# Altium schematic sheet TPVSI_Control_Part.SchDoc(TPVSI_Control_Part)
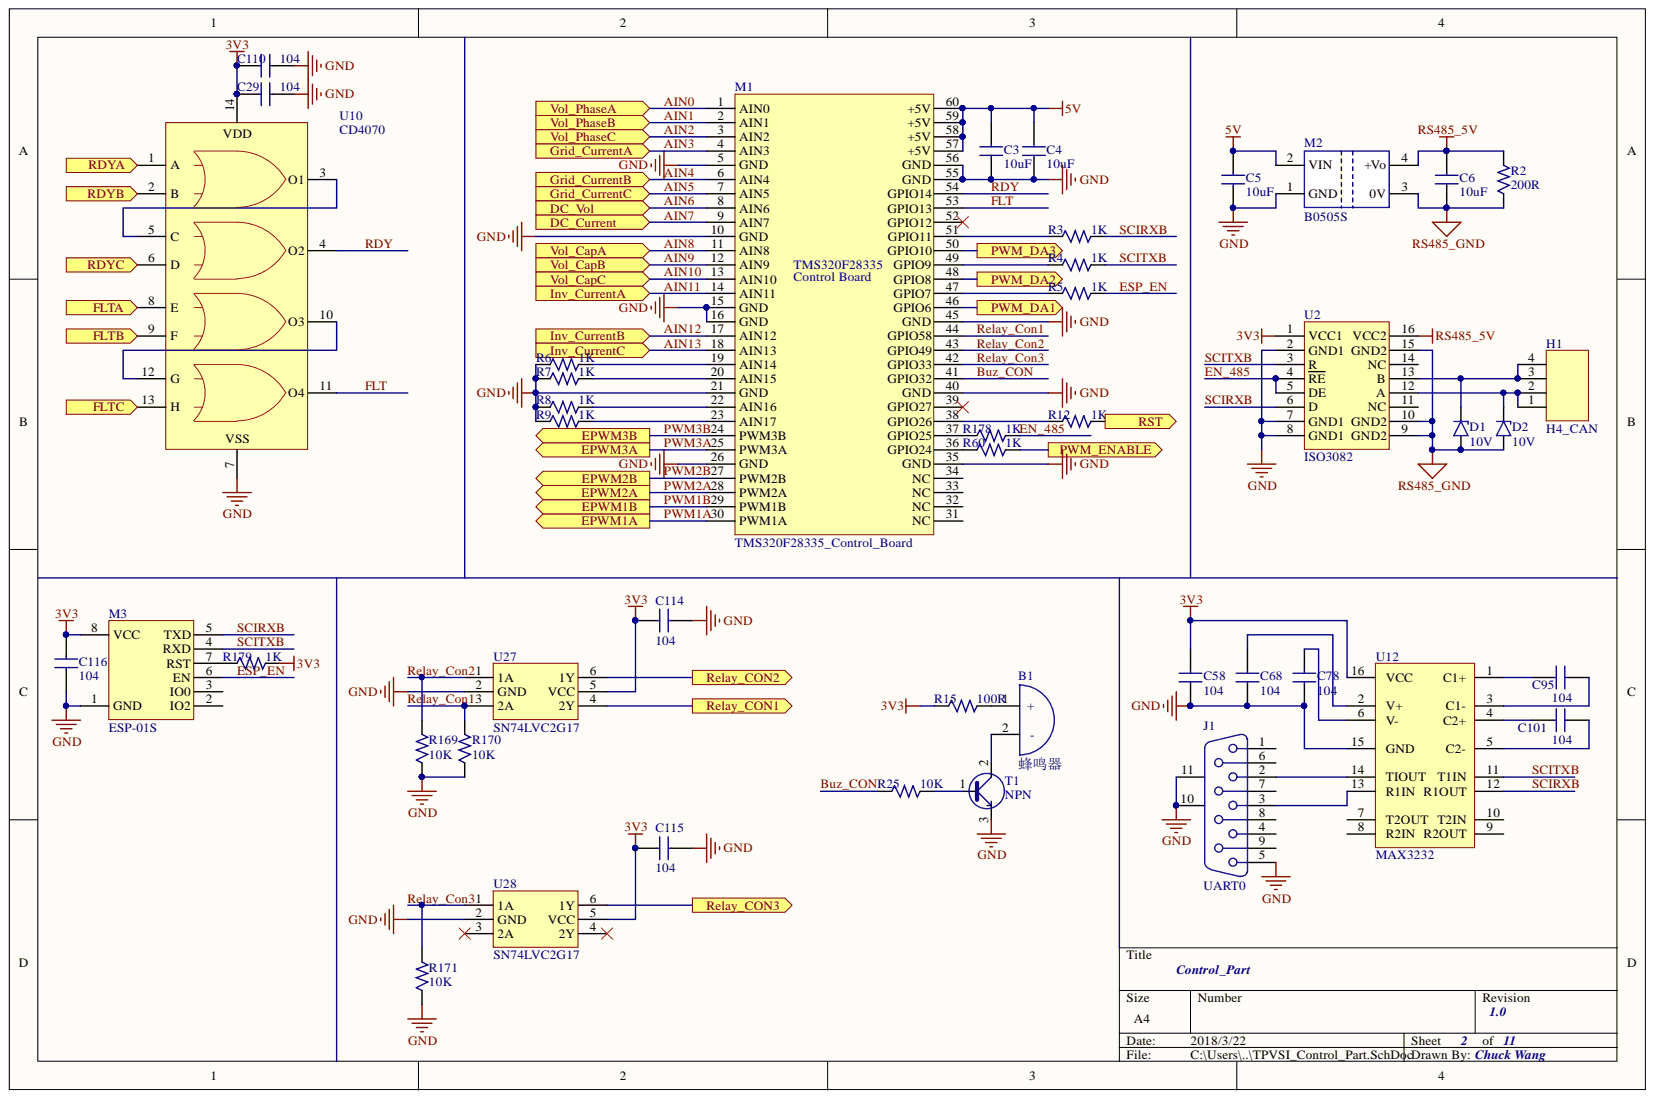
[diagram p0: TPVSI_Control_Part.SchDoc]

## Parts
B1  蜂鸣器  [Buz_9032]
C3  10uF  [1210]
C4  10uF  [1210]
C5  10uF  [1210]
C6  10uF  [1210]
C29  104  [C-RAD-0603]
C58  104  [C-RAD-0603]
C68  104  [C-RAD-0603]
C78  104  [C-RAD-0603]
C95  104  [C-RAD-0603]
C101  104  [C-RAD-0603]
C110  104  [C-RAD-0603]
C114  104  [C-RAD-0603]
C115  104  [C-RAD-0603]
C116  104  [C-RAD-0603]
D1  TVS  [DIODE-SMA]  (value not drawn)
D2  TVS  [DIODE-SMA]  (value not drawn)
H1  H4_CAN  [H4_CAN]
J1  UART0  [串口接口]
M1  TMS320F28335_Control_Board  [TMS320F28335_Control_Board]
M2  B0505S  [b0505s]
M3  ESP-01S  [ESP-01S]
R2  200R  [1206]
R3  1K  [0603_1]
R4  1K  [0603_1]
R5  1K  [0603_1]
R6  1K  [0603_1]
R7  1K  [0603_1]
R8  1K  [0603_1]
R9  1K  [0603_1]
R12  1K  [0603_1]
R15  100R  [1206]
R25  10K  [0603_1]
R60  1K  [0603_1]
R169  10K  [0603_1]
R170  10K  [0603_1]
R171  10K  [0603_1]
R178  1K  [0603_1]
R179  1K  [0603_1]
T1  NPN  [SOT-23]
U2  ISO3082  [SOIC16-WideBody]
U10  CD4070  [SOP-14N]
U12  MAX3232  [NSO16]
U27  SN74LVC2G17  [SOT23-6]
U28  SN74LVC2G17  [SOT23-6]

## Ports
DC_Current, DC_Vol, EPWM1A, EPWM1B, EPWM2A, EPWM2B, EPWM3A, EPWM3B, FLTA, FLTB, FLTC, Grid_CurrentA, Grid_CurrentB, Grid_CurrentC, Inv_CurrentA, Inv_CurrentB, Inv_CurrentC, PWM_DA1, PWM_DA2, PWM_DA3, PWM_ENABLE, RDYA, RDYB, RDYC, Relay_CON1, Relay_CON2, Relay_CON3, RST, Vol_CapA, Vol_CapB, Vol_CapC, Vol_PhaseA, Vol_PhaseB, Vol_PhaseC

## Nets
3V3: C29.1 C58.2 C110.1 C114.1 C115.1 C116.2 M3.8(VCC) R15.1 R179.2 U2.1(VCC1) U10.14 U12.16(VCC) U27.5(VCC) U28.5(VCC)
5V: C3.2 C4.2 C5.2 M1.57(+5V) M1.58(+5V) M1.59(+5V) M1.60(+5V) M2.2(VIN)
GND: C3.1 C4.1 C5.1 C29.2 C58.1 C68.1 C78.1 C110.2 C114.2 C115.2 C116.1 J1.5 J1.10 J1.11 M1.5(GND) M1.10(GND) M1.15(GND) M1.16(GND) M1.21(GND) M1.26(GND) M1.35(GND) M1.40(GND) M1.45(GND) M1.55(GND) M1.56(GND) M2.1(GND) M3.1(GND) R6.1 R7.1 R8.1 R9.1 R169.1 R170.1 R171.1 T1.3 U2.2(GND1) U2.7(GND1) U2.8(GND1) U10.7 U12.15(GND) U27.2(GND) U28.2(GND)
RS485_5V: C6.2 M2.4(+Vo) R2.2 U2.16(VCC2)
RS485_GND: C6.1 D1.1 D2.1 M2.3(0V) R2.1 U2.9(GND2) U2.10(GND2) U2.15(GND2)
AIN0: M1.1(AIN0) ; port Vol_PhaseA
AIN1: M1.2(AIN1) ; port Vol_PhaseB
AIN2: M1.3(AIN2) ; port Vol_PhaseC
AIN3: M1.4(AIN3) ; port Grid_CurrentA
AIN4: M1.6(AIN4) ; port Grid_CurrentB
AIN5: M1.7(AIN5) ; port Grid_CurrentC
AIN6: M1.8(AIN6) ; port DC_Vol
AIN7: M1.9(AIN7) ; port DC_Current
AIN8: M1.11(AIN8) ; port Vol_CapA
AIN9: M1.12(AIN9) ; port Vol_CapB
AIN10: M1.13(AIN10) ; port Vol_CapC
AIN11: M1.14(AIN11) ; port Inv_CurrentA
AIN12: M1.17(AIN12) ; port Inv_CurrentB
AIN13: M1.18(AIN13) ; port Inv_CurrentC
Buz_CON: M1.41(GPIO32) R25.1
EN_485: R178.2 U2.4(RE) U2.5(DE)
ESP_EN: M3.6(EN) R5.2
FLT: M1.53(GPIO13) U10.11(O4)
FLTA: U10.8(E) ; port FLTA
FLTB: U10.9(F) ; port FLTB
FLTC: U10.13(H) ; port FLTC
PWM1A: M1.30(PWM1A) ; port EPWM1A
PWM1B: M1.29(PWM1B) ; port EPWM1B
PWM2A: M1.28(PWM2A) ; port EPWM2A
PWM2B: M1.27(PWM2B) ; port EPWM2B
PWM3A: M1.25(PWM3A) ; port EPWM3A
PWM3B: M1.24(PWM3B) ; port EPWM3B
PWM_DA1: M1.46(GPIO6) ; port PWM_DA1
PWM_DA2: M1.48(GPIO8) ; port PWM_DA2
PWM_DA3: M1.50(GPIO10) ; port PWM_DA3
PWM_ENABLE: R60.2 ; port PWM_ENABLE
RDY: M1.54(GPIO14) U10.4(O2)
RDYA: U10.1(A) ; port RDYA
RDYB: U10.2(B) ; port RDYB
RDYC: U10.6(D) ; port RDYC
Relay_CON1: U27.4(2Y) ; port Relay_CON1
Relay_Con1: M1.44(GPIO58) R170.2 U27.3(2A)
Relay_CON2: U27.6(1Y) ; port Relay_CON2
Relay_Con2: M1.43(GPIO49) R169.2 U27.1(1A)
Relay_CON3: U28.6(1Y) ; port Relay_CON3
Relay_Con3: M1.42(GPIO33) R171.2 U28.1(1A)
RST: R12.2 ; port RST
SCIRXB: M3.5(TXD) R3.2 U2.6(D) U12.12(R1OUT)
SCITXB: M3.4(RXD) R4.2 U2.3(R) U12.11(T1IN)
NetB1_1: B1.1 R15.2
NetB1_2: B1.2 T1.2
NetC68_2: C68.2 U12.2(V+)
NetC78_2: C78.2 U12.6(V-)
NetC95_1: C95.1 U12.3(C1-)
NetC95_2: C95.2 U12.1(C1+)
NetC101_1: C101.1 U12.5(C2-)
NetC101_2: C101.2 U12.4(C2+)
NetD1_2: D1.2 H1.3 H1.4 U2.13(B)
NetD2_2: D2.2 H1.1 H1.2 U2.12(A)
NetJ1_2: J1.2 U12.14(TIOUT)
NetJ1_3: J1.3 U12.13(R1IN)
NetM1_19: M1.19(AIN14) R6.2
NetM1_20: M1.20(AIN15) R7.2
NetM1_22: M1.22(AIN16) R8.2
NetM1_23: M1.23(AIN17) R9.2
NetM1_36: M1.36(GPIO24) R60.1
NetM1_37: M1.37(GPIO25) R178.1
NetM1_38: M1.38(GPIO26) R12.1
NetM1_47: M1.47(GPIO7) R5.1
NetM1_49: M1.49(GPIO9) R4.1
NetM1_51: M1.51(GPIO11) R3.1
NetM3_7: M3.7(RST) R179.1
NetR25_2: R25.2 T1.1
NetU10_3: U10.3(O1) U10.5(C)
NetU10_10: U10.10(O3) U10.12(G)

Not connected: J1 1 4 6 7 8 9; M1 31(NC) 32(NC) 33(NC) 34(NC) 39(GPIO27) 52(GPIO12); M3 2(IO2) 3(IO0); U2 11(NC) 14(NC); U12 7(T2OUT) 8(R2IN) 9(R2OUT) 10(T2IN); U28 3(2A) 4(2Y)

## Library data of the parts
B1 = Buzzer: Component Kind: Standard; Library Name: Chu_16.IntLib
C3-C6 C29 C58 C68 C78 C95 C101 C110 C114-C116 = cap: Component Kind: Standard; Library Name: Chu_16.IntLib
D1 D2 = Diode_TVS: Component Kind: Standard; : 10V; Library Name: Chu_16.IntLib
J1 = 串口: Receptacle Assembly, 9 Position, Right Angle; Positions: 9; PackageDocument: O; Published: 15-Jun-2001; PackageReference: 788750; Orientation: Right Angle; LatestRevisionNote: Re-released for DXP Platform.; LatestRevisionDate: 17-Jul-2002; Gender: Receptacle; DatasheetDocument: 1-Sep-1999; Component Kind: Standard; Publisher: Altium Limited; Library Name: 机器人队元件库 .IntLib
M3 = ESP-01S: WIFI模块; Component Kind: Standard; Library Name: Chu_16.IntLib
R2-R9 R12 R15 R25 R60 R169-R171 R178 R179 = Res: Component Kind: Standard; Library Name: Chu_16.IntLib
T1 = NPN_BJT: Component Kind: Standard; Library Name: Chu_16.IntLib
U27 U28 = SN74LVC3G07: Component Kind: Standard; Library Name: Chu_16.IntLib
H1 = H4_CAN: Component Kind: Standard; Library Name: 机器人队元件库 .IntLib
M1 = TMS320F28335_Control_Board: Component Kind: Standard; Library Name: Chu_16.IntLib
M2 = B0505S: Component Kind: Standard; Library Name: Chu_16.IntLib
U2 = ISO3082: Component Kind: Standard; Library Name: Chu_16.IntLib
U10 = CD4070: Component Kind: Standard; Library Name: Chu_16.IntLib
U12 = MAX3232: Component Kind: Standard; Library Name: 机器人队元件库 .IntLib
